# Altium schematic sheet POWER.SchDoc
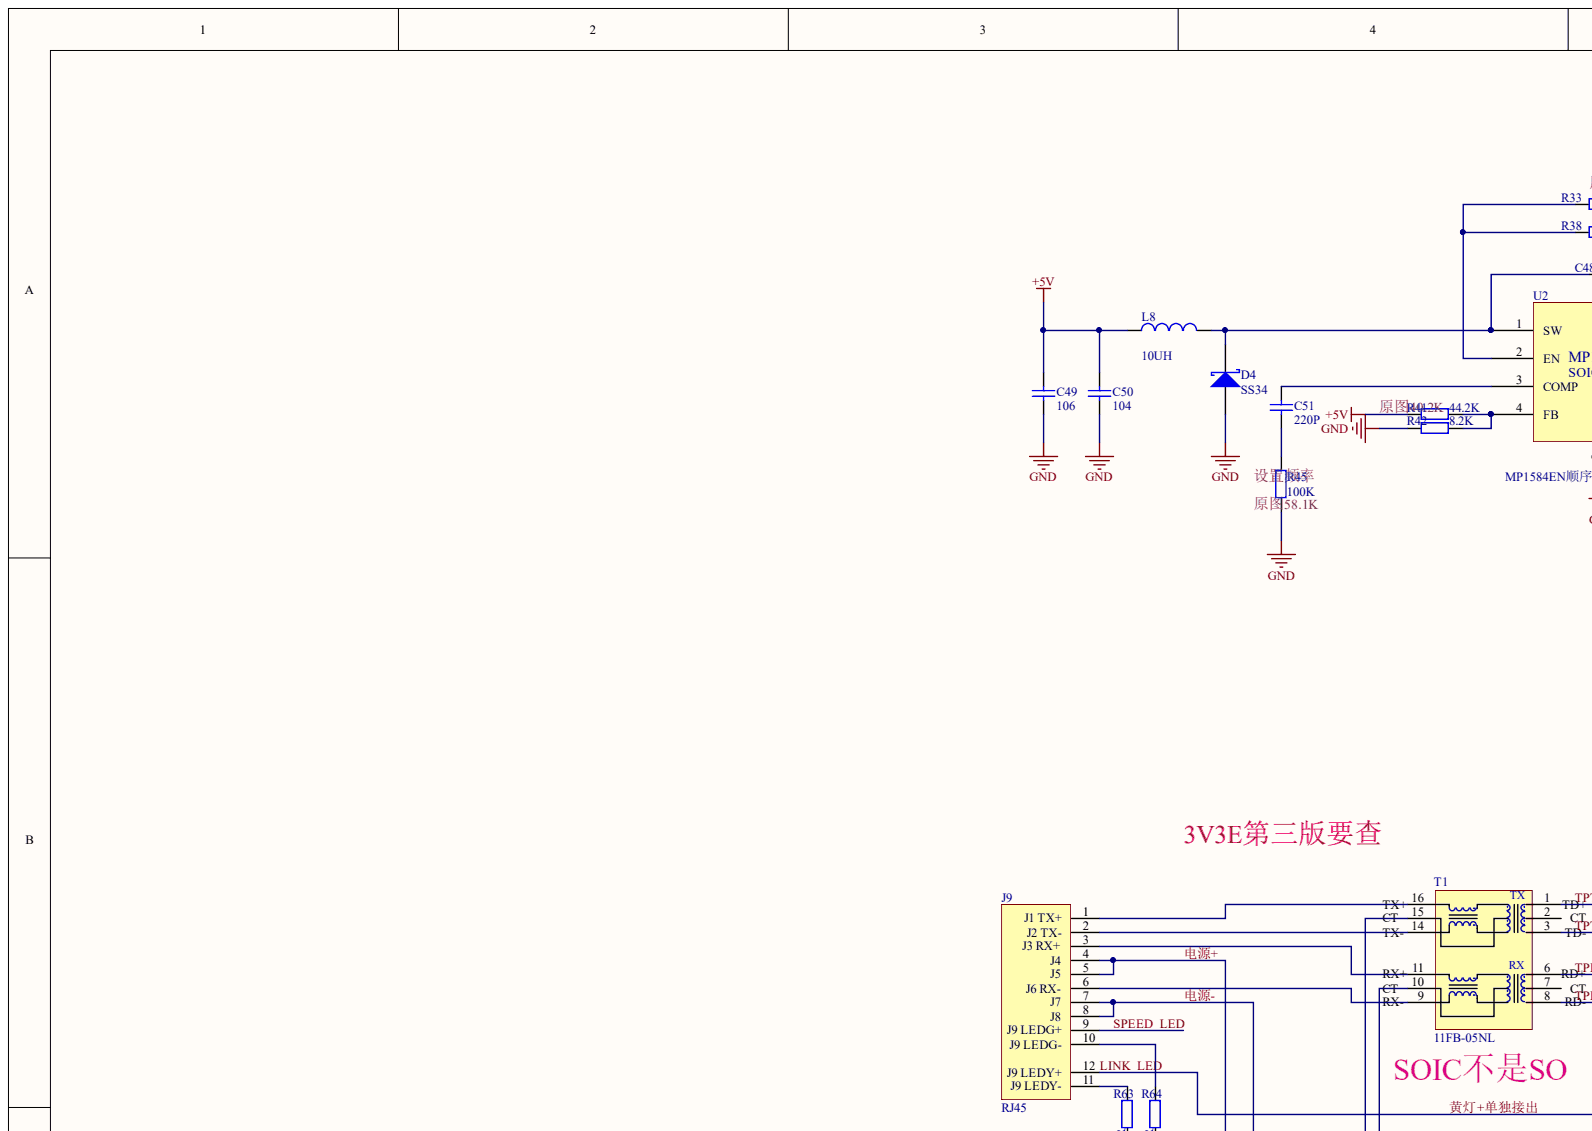
[diagram p0: POWER.SchDoc, top left]
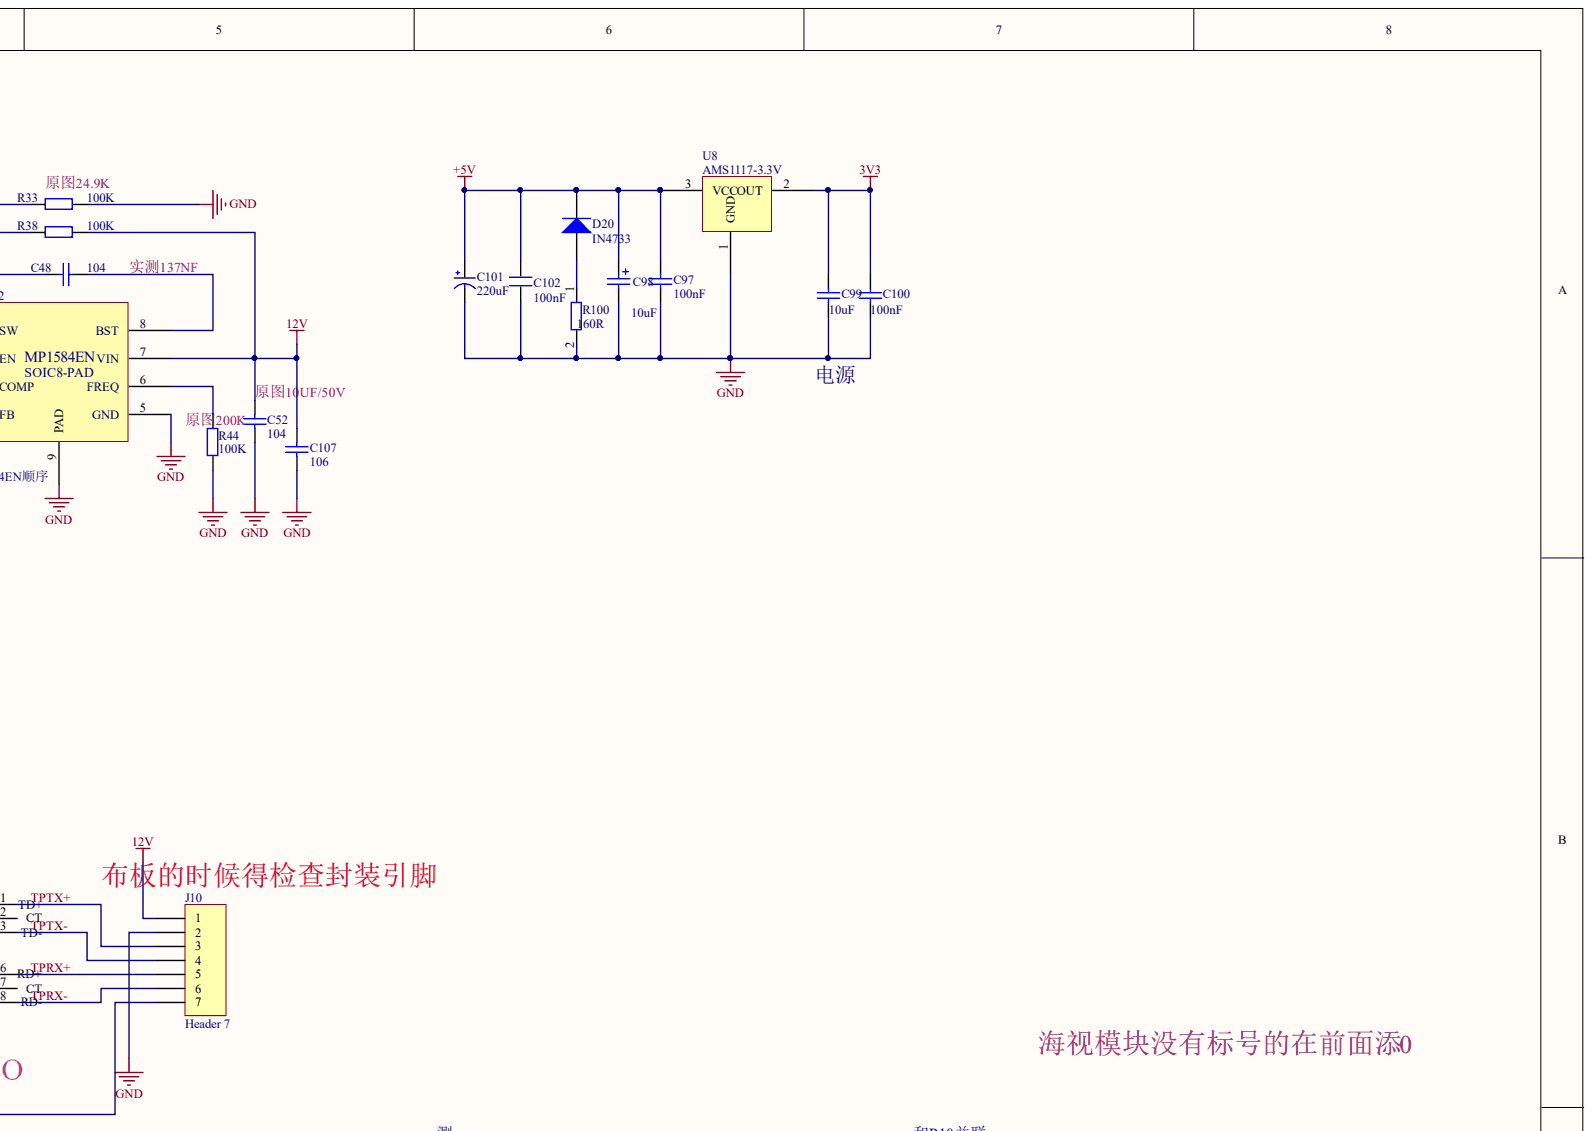
[diagram p1: POWER.SchDoc, top right]
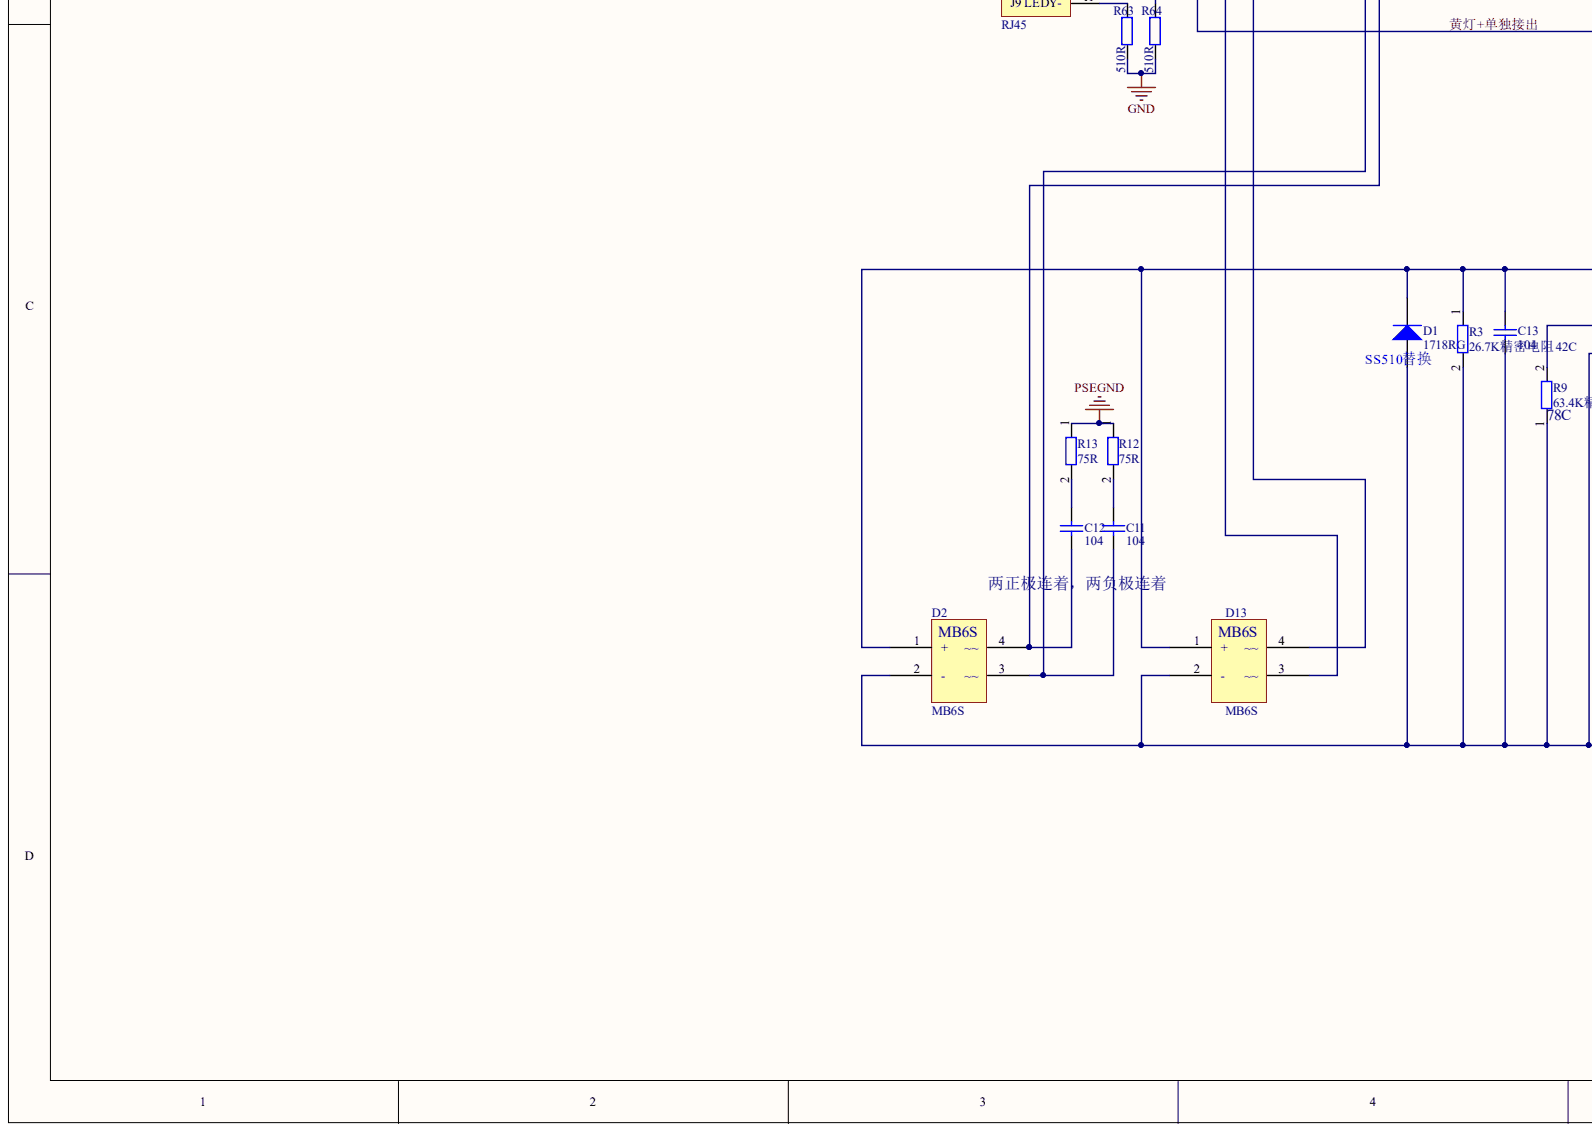
[diagram p2: POWER.SchDoc, bottom left]
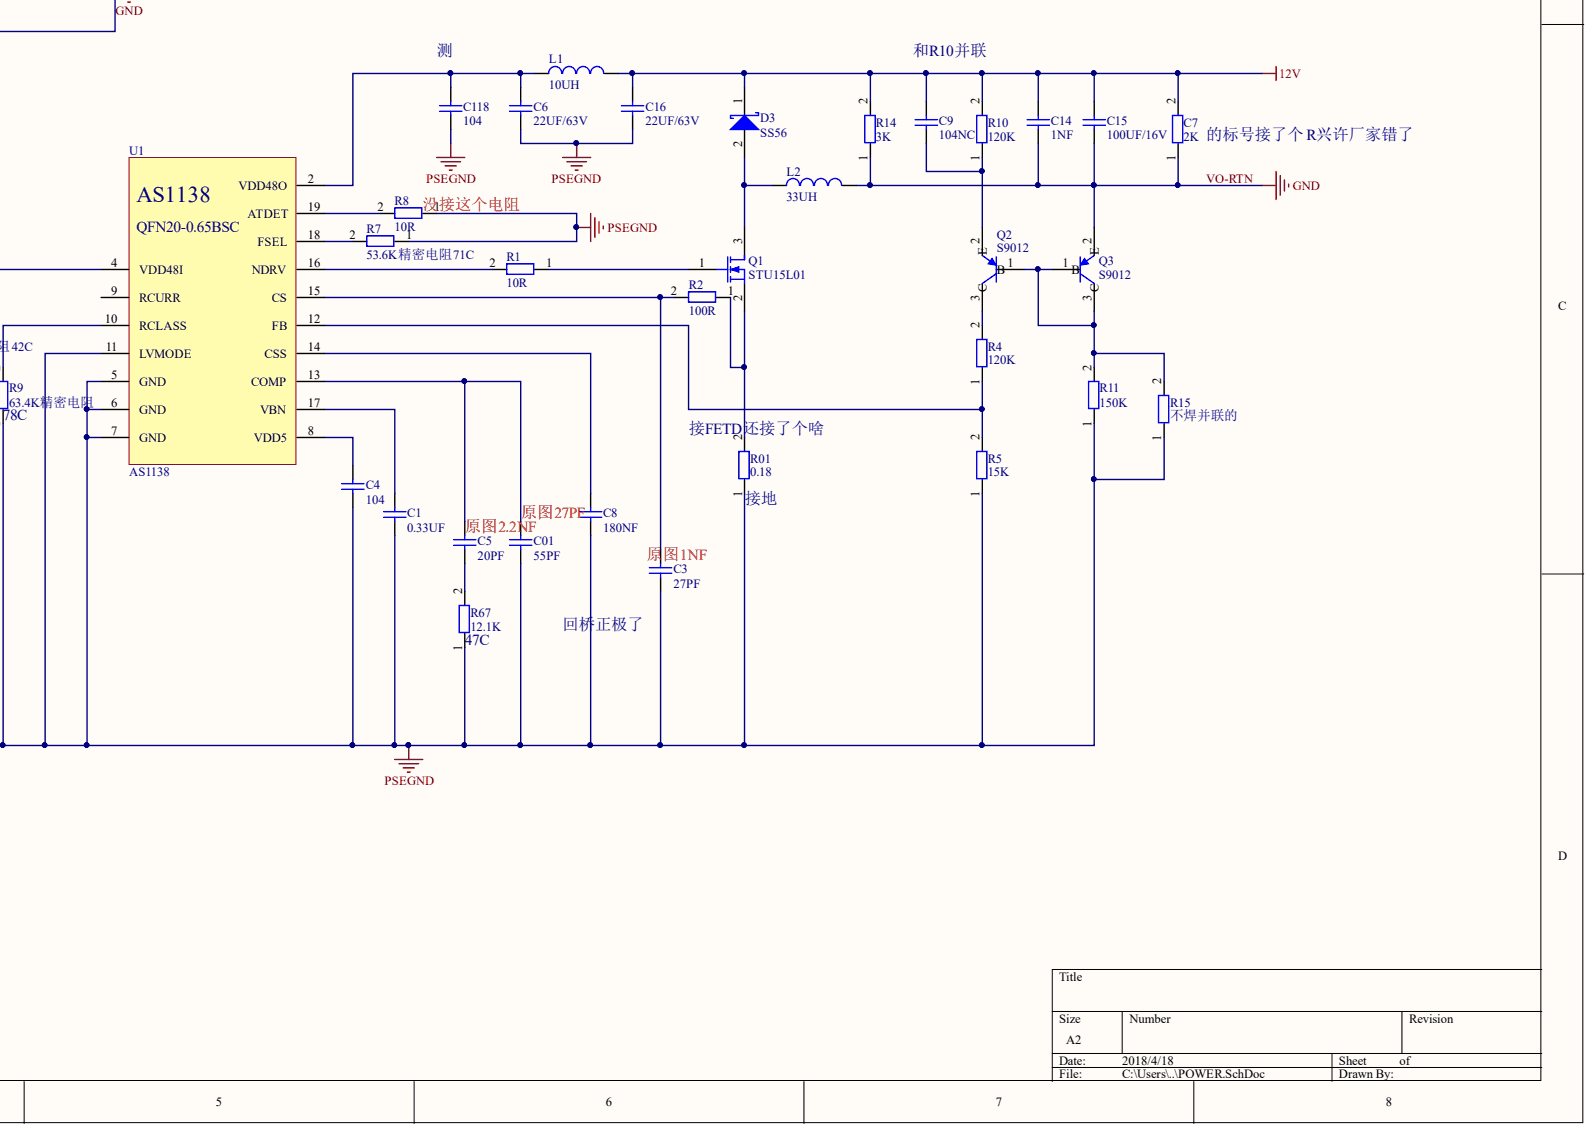
[diagram p3: POWER.SchDoc, bottom right]

## Parts
C01  55PF  [0603T]
C1  0.33UF  [0603T]
C3  27PF  [0603T]
C4  104  [0603T]
C5  20PF  [0603T]
C6  22UF/63V  [CAP-SIP]
C7  2K  [0603T]
C8  180NF  [0603T]
C9  104NC  [0603T]
C11  104  [0603T]
C12  104  [0603T]
C13  104  [0603T]
C14  1NF  [1206]
C15  100UF/16V  [CAP-SIP]
C16  22UF/63V  [CAP-SIP]
C48  104  [0603T]
C49  106  [0603T]
C50  104  [0603T]
C51  220P  [0603T]
C52  104  [0603T]
C97  100nF  [0603T]
C98  10uF  [0603T]
C99  10uF  [0603T]
C100  100nF  [0603T]
C101  220uF  [7343E型]
C102  100nF  [0603T]
C107  106  [0603T]
C118  104  [0603T]
D1  1718RG  [SMB]
D2  MB6S  [SOP-4]
D3  SS56  [SMC]
D4  SS34  [SMB]
D13  MB6S  [SOP-4]
D20  IN4733  [SMB]
J9  RJ45  [RJ45]
J10  Header 7  [PH2.0立直-7PIN]
L1  10UH  [4*4电感]
L2  33UH  [L10*10]
L8  value 10UH  [6*6电感]  (hidden comment: Inductor)
Q1  STU15L01  [TO-252AA(D-PAK)]
Q2  S9012  [SOT-23]
Q3  S9012  [SOT-23]
R01  0.18  [3.5X2.8X1.9]
R1  10R  [0603T]
R2  100R  [0603T]
R3  26.7K精密电阻42C  [0603T]
R4  120K  [0603T]
R5  15K  [0603T]
R7  53.6K精密电阻71C  [0603T]
R8  10R  [0603T]
R9  63.4K精密电阻  [0603T]
R10  120K  [0603T]
R11  150K  [0603T]
R12  75R  [0603T]
R13  75R  [0603T]
R14  3K  [0603T]
R15  不焊并联的  [0603T]
R33  100K  [0603T]
R38  100K  [0603T]
R41  44.2K  [0603T]
R42  8.2K  [0603T]
R44  100K  [0603T]
R45  100K  [0603T]
R63  value 510R  [0603T]  (hidden comment: Res2)
R64  value 510R  [0603T]  (hidden comment: Res2)
R67  12.1K  [0603T]
R100  160R  [0603T]
T1  11FB-05NL  [SOIC16]
U1  AS1138  [QFN20-0.65BSC-PAD]
U2  MP1584EN顺序  [SOIC8-PAD]
U8  AMS1117-3.3V  [SOT-223]

## Nets
3V3: C99.2 C100.2 U8.2
12V: C7.2 C9.1 C14.2 C15.2 C16.2 C52.2 C107.2 D3.1 J10.1 L1.2 R10.2 R14.2 R38.2 U2.7(VIN)
+5V: C49.2 C50.2 C97.2 C98.1 C101.1 C102.2 D20.2 L8.1 R41.2 U8.3
PSEGND: C01.2 C1.2 C3.2 C4.2 C6.1 C8.2 C13.2 C16.1 C118.1 D1.1 D2.2(-) D13.2(-) R01.1 R3.2 R5.1 R7.1 R8.1 R9.1 R11.1 R12.1 R13.1 R15.1 R67.1 U1.5(GND) U1.6(GND) U1.7(GND) U1.11(LVMODE)
LINK_LED: J9.12(LEDY+) J10.7
SPEED_LED: J9.9(LEDG+)
TPRX+: J10.5 T1.6
TPRX-: J10.6 T1.8
TPTX+: J10.3 T1.1
TPTX-: J10.4 T1.3
VO-RTN: C7.1 C14.1 C15.1 C49.1 C50.1 C52.1 C97.1 C98.2 C99.1 C100.1 C101.2 C102.1 C107.1 D4.1 J10.2 L2.2 Q3.2 R14.1 R33.2 R42.2 R44.2 R45.2 R63.2 R64.2 R100.2 U2.5(GND) U2.9(PAD) U8.1
电源+: D13.3(~~) J9.4(J4) J9.5(J5)
电源-: D13.4(~~) J9.7(J7) J9.8(J8)
NetC01_1: C01.1 C5.1 U1.13(COMP)
NetC1_1: C1.1 U1.17(VBN)
NetC3_1: C3.1 R2.2 U1.15(CS)
NetC4_1: C4.1 U1.8(VDD5)
NetC5_2: C5.2 R67.2
NetC6_2: C6.2 C118.2 L1.1 U1.2(VDD48O)
NetC8_1: C8.1 U1.14(CSS)
NetC9_2: C9.2 Q2.2 R10.1
NetC11_1: C11.1 R12.2
NetC11_2: C11.2 D2.3(~~) T1.15
NetC12_1: C12.1 R13.2
NetC12_2: C12.2 D2.4(~~) T1.10
NetC13_1: C13.1 D1.2 D2.1(+) D13.1(+) R3.1 U1.4(VDD48I)
NetC48_1: C48.1 U2.8(BST)
NetC48_2: C48.2 D4.2 L8.2 U2.1(SW)
NetC51_1: C51.1 R45.1
NetC51_2: C51.2 U2.3(COMP)
NetD3_2: D3.2 L2.1 Q1.3
NetD20_1: D20.1 R100.1
NetJ9_1: J9.1(J1_TX+) T1.16
NetJ9_2: J9.2(J2_TX-) T1.14
NetJ9_3: J9.3(J3_RX+) T1.11
NetJ9_6: J9.6(J6_RX-) T1.9
NetJ9_10: J9.10(LEDG-) R64.1
NetJ9_11: J9.11(LEDY-) R63.1
NetQ1_1: Q1.1 R1.1
NetQ1_2: Q1.2 R01.2 R2.1
NetQ2_1: Q2.1 Q3.1 Q3.3 R11.2 R15.2
NetQ2_3: Q2.3 R4.2
NetR1_2: R1.2 U1.16(NDRV)
NetR4_1: R4.1 R5.2 U1.12(FB)
NetR7_2: R7.2 U1.18(FSEL)
NetR8_2: R8.2 U1.19(ATDET)
NetR9_2: R9.2 U1.10(RCLASS)
NetR33_1: R33.1 R38.1 U2.2(EN)
NetR41_1: R41.1 R42.1 U2.4(FB)
NetR44_1: R44.1 U2.6(FREQ)

Not connected: T1 2 7; U1 9(RCURR)

## Library data of the parts
C01 C1 C3-C6 C8 C9 C11-C16 C48-C52 C107 C118 = Cap: Capacitor; Value: 100pF; Component Kind: Standard; LatestRevisionDate: 17-Jul-2002; LatestRevisionNote: Re-released for DXP Platform.; Library Name: Miscellaneous Devices.IntLib; PackageDescription: Radial Cap, Thru-Hole; 2 Leads; 0.3 in Pin Spacing; PackageReference: RAD-0.3; Published: 8-Jun-2000; Signal Integrity: Cap; Simulation: CAP; Publisher: Altium Limited
C7 R01 R1-R5 R7-R15 R33 R38 R41 R42 R44 R45 R67 R100 = Res2: Resistor; Value: 1K; Component Kind: Standard; LatestRevisionDate: 17-Jul-2002; LatestRevisionNote: Re-released for DXP Platform.; Library Name: Miscellaneous Devices.IntLib; PackageDescription: Axial Device, Thru-Hole; 2 Leads; 0.4 in Pin Spacing; PackageReference: AXIAL-0.4; Published: 8-Jun-2000; Signal Integrity: Res; Simulation: RESISTOR; Publisher: Altium Limited
C97 C99 C100 = Cap: Capacitor; Component Kind: Standard; LatestRevisionDate: 17-Jul-2002; LatestRevisionNote: Re-released for DXP Platform.; Library Name: Miscellaneous Devices.IntLib; PackageDescription: Radial Cap, Thru-Hole; 2 Leads; 0.3 in Pin Spacing; PackageReference: RAD-0.3; Published: 8-Jun-2000; Signal Integrity: Cap; Simulation: CAP; Publisher: Altium Limited
  C97 C100: Value: 100nF
  C99: Value: 10uF
C98 = Cap Pol2: Polarized Capacitor (Axial); Component Kind: Standard; LatestRevisionDate: 17-Jul-2002; LatestRevisionNote: Re-released for DXP Platform.; Library Name: Miscellaneous Devices.IntLib; PackageDescription: Polarized Capacitor; 2 Leads; PackageReference: POLAR0.8; Published: 8-Jun-2000; Signal Integrity: Cap Pol; Simulation: CAP; Value: 10uF; Publisher: Altium Limited
C101 = CAP: Component Kind: Standard; Library Name: EXPLORER.SCHLIB
C102 = C: Component Kind: Standard; Library Name: EXPLORER.SCHLIB
D1 D20 = Diode: Default Diode; Code_JEDEC: DO-214-AB; Component Kind: Standard; LatestRevisionDate: 17-Jul-2002; LatestRevisionNote: Re-released for DXP Platform.; Library Name: Miscellaneous Devices.IntLib; PackageDescription: DO-214-AB/SMC; 2 C-Bend Leads; Body 7.9 x 5.9 mm, inc. leads (LxW); PackageReference: SMC; PackageVersion: Sep-1996; Published: 8-Jun-2000; Signal Integrity: Diode; Simulation: DIODE; Publisher: Altium Limited
D3 = Diode 10TQ035: Schottky Rectifier; Note: Terminal 2 is the TAB and is electrically connected to the cathode; Component Kind: Standard; ComponentLink1Description: Manufacturer Link; ComponentLink1URL: http://www.irf.com/; ComponentLink2Description: Datasheet; ComponentLink2URL: http://www.irf.com/product-info/datasheets/data/10tq.pdf; DatasheetVersion: PD-2.057B; LatestRevisionDate: 17-Jul-2002; LatestRevisionNote: Re-released for DXP Platform.; Library Name: Miscellaneous Devices.IntLib; PackageDescription: TO, Thru-Hole, Vertical, Heatsink Mounted; 3 In-Line Leads (1 Absent); Pitch 2.54 mm; PackageReference: TO-220AC; PackageVersion: Feb-1998; Published: 8-Jun-2000; Simulation: 10TQ035; Publisher: Altium Limited
D4 = D Schottky: Schottky Diode; Code_JEDEC: DO-214-AA; Component Kind: Standard; LatestRevisionDate: 17-Jul-2002; LatestRevisionNote: Re-released for DXP Platform.; Library Name: Miscellaneous Devices.IntLib; PackageDescription: DO-214-AA/SMB; 2 C-Bend Leads; Body 5.3 x 3.6 mm, inc. leads (LxW); PackageReference: SMB; Published: 8-Jun-2000; Signal Integrity: D Schottky; Simulation: SKYDIODE; Publisher: Altium Limited
J10 = Header 7: Header, 7-Pin; Component Kind: Standard; LatestRevisionDate: 17-Jul-2002; LatestRevisionNote: Re-released for DXP Platform.; Signal Integrity: Connector; Library Name: Sui.SCHLIB; Publisher: Altium Limited
L1 L8 = Inductor: Code_IEC: 1005; Code_JEITA: 0402; Component Kind: Standard; Description: Inductor; LatestRevisionDate: 29-May-2009; LatestRevisionNote: IPC-7351 Footprint Added.; Library Name: Miscellaneous Devices.IntLib; PackageDescription: Chip Inductor; PackageReference: 0402-A; Published: 8-Jun-2000; Publisher: Altium Limited
  L1: Value: 10UH
  L8: Signal Integrity: Inductor; Simulation: INDUCTOR
L2 = Inductor: Code_IEC: 1005; Value: 10UH; Code_JEITA: 0402; Component Kind: Standard; Description: Inductor; LatestRevisionDate: 29-May-2009; LatestRevisionNote: IPC-7351 Footprint Added.; Library Name: Miscellaneous Devices.IntLib; PackageDescription: Chip Inductor; PackageReference: 0402-A; Published: 8-Jun-2000; Publisher: Altium Limited
Q1 = MOSFET-N: N-Channel MOSFET; Component Kind: Standard; LatestRevisionDate: 17-Jul-2002; LatestRevisionNote: Re-released for DXP Platform.; Library Name: Miscellaneous Devices.IntLib; PackageDescription: TO, Flat Index; 3 In-Line, Axial Leads; Body Dia. 4.6mm; Lead Dia. 0.5 mm (max); PackageReference: E3; PackageVersion: 1995/1996; Published: 8-Jun-2000; Simulation: NMOS; Publisher: Altium Limited
Q2 Q3 = S9012: NPN General Purpose Amplifier; Component Kind: Standard; ComponentLink1Description: Manufacturer Link; ComponentLink1URL: http://www.fairchildsemi.com/; ComponentLink2Description: Datasheet; ComponentLink2URL: http://www.fairchildsemi.com/ds/2N%2F2N3904.pdf; DatasheetVersion: 1997; LatestRevisionDate: 15-Jan-2003; LatestRevisionNote: PCB Footprint 'BCY-W3/D4.7' replaced; PackageDescription: TO, Flat Index; 3 In-Line, Axial Leads; Body Dia. 4.5mm; Leads 0.51 x 0.48 mm (max); PackageReference: TO-92A; PackageVersion: Sep-1998; Published: 8-Jun-2000; Signal Integrity: 2N3904; Simulation: 2N3904; Library Name: Sui.SCHLIB; Publisher: Altium Limited
R63 R64 = Res2: Resistor; Component Kind: Standard; LatestRevisionDate: 17-Jul-2002; LatestRevisionNote: Re-released for DXP Platform.; Library Name: Miscellaneous Devices.IntLib; PackageDescription: Axial Device, Thru-Hole; 2 Leads; 0.4 in Pin Spacing; PackageReference: AXIAL-0.4; Published: 8-Jun-2000; Signal Integrity: Res; Simulation: RESISTOR; Publisher: Altium Limited
U8 = 11: Component Kind: Standard
D2 D13 = MB6S: Component Kind: Standard; Library Name: Sui.SCHLIB
J9 = RJ45: Component Kind: Standard; Library Name: Sui.SCHLIB
T1 = 11FB-05NL: Component Kind: Standard; Library Name: Sui.SCHLIB
U1 = AS1138: Component Kind: Standard; Library Name: Sui.SCHLIB
U2 = MP1584EN顺序: Component Kind: Standard; Library Name: Sui.SCHLIB
